# Altium schematic sheet FTDI_FT232R_USB_To_UART.SchDoc
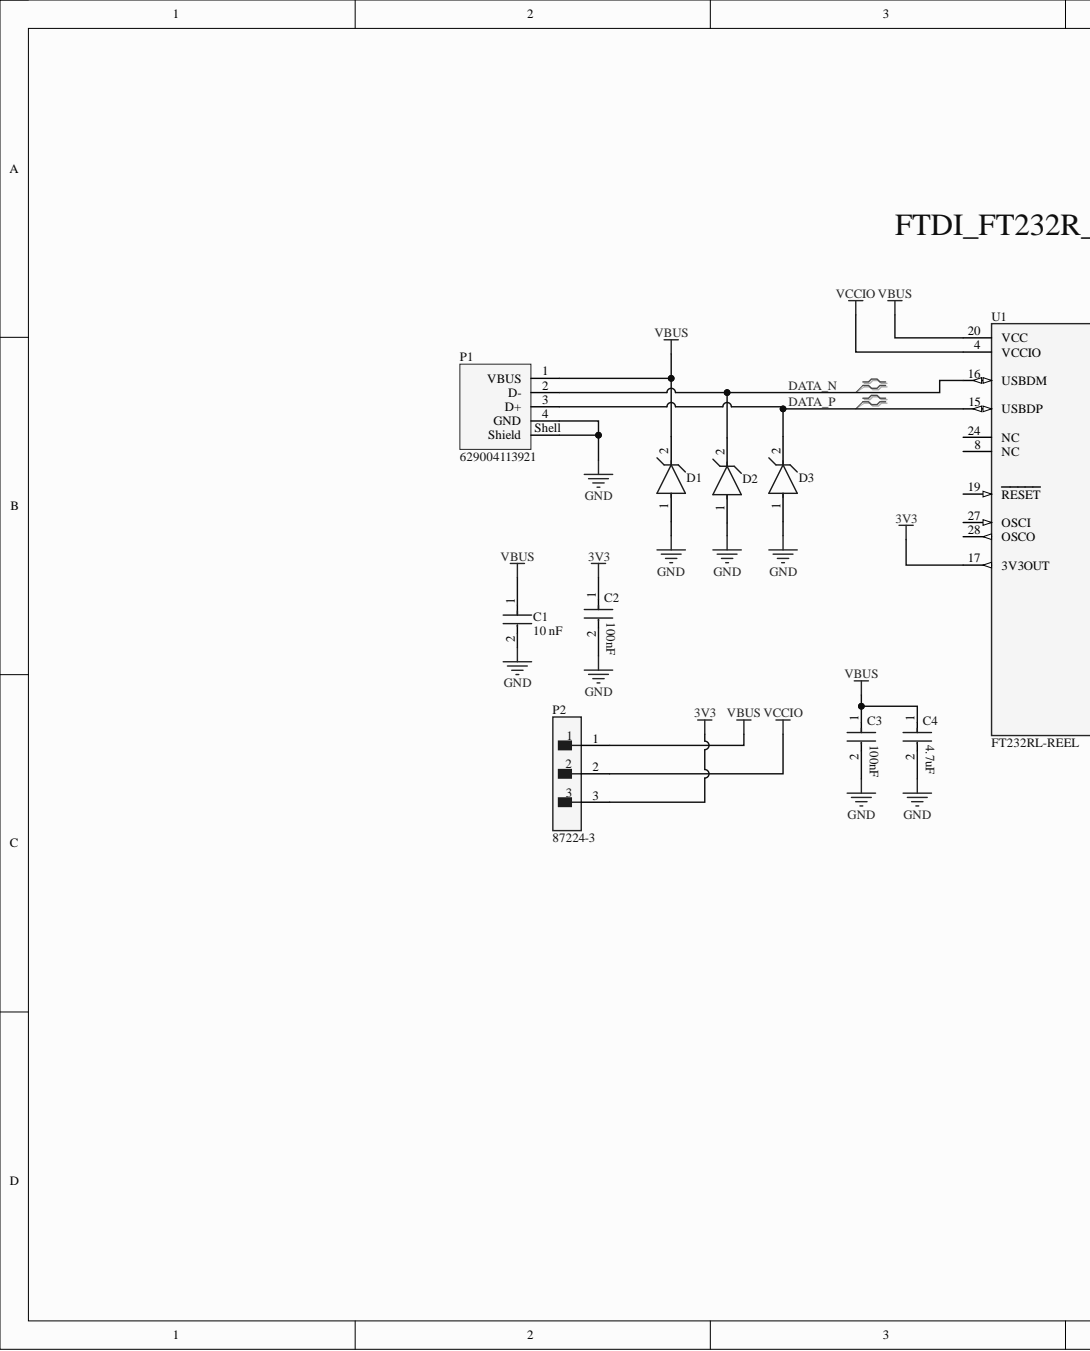
[diagram p0: FTDI_FT232R_USB_To_UART.SchDoc, left]
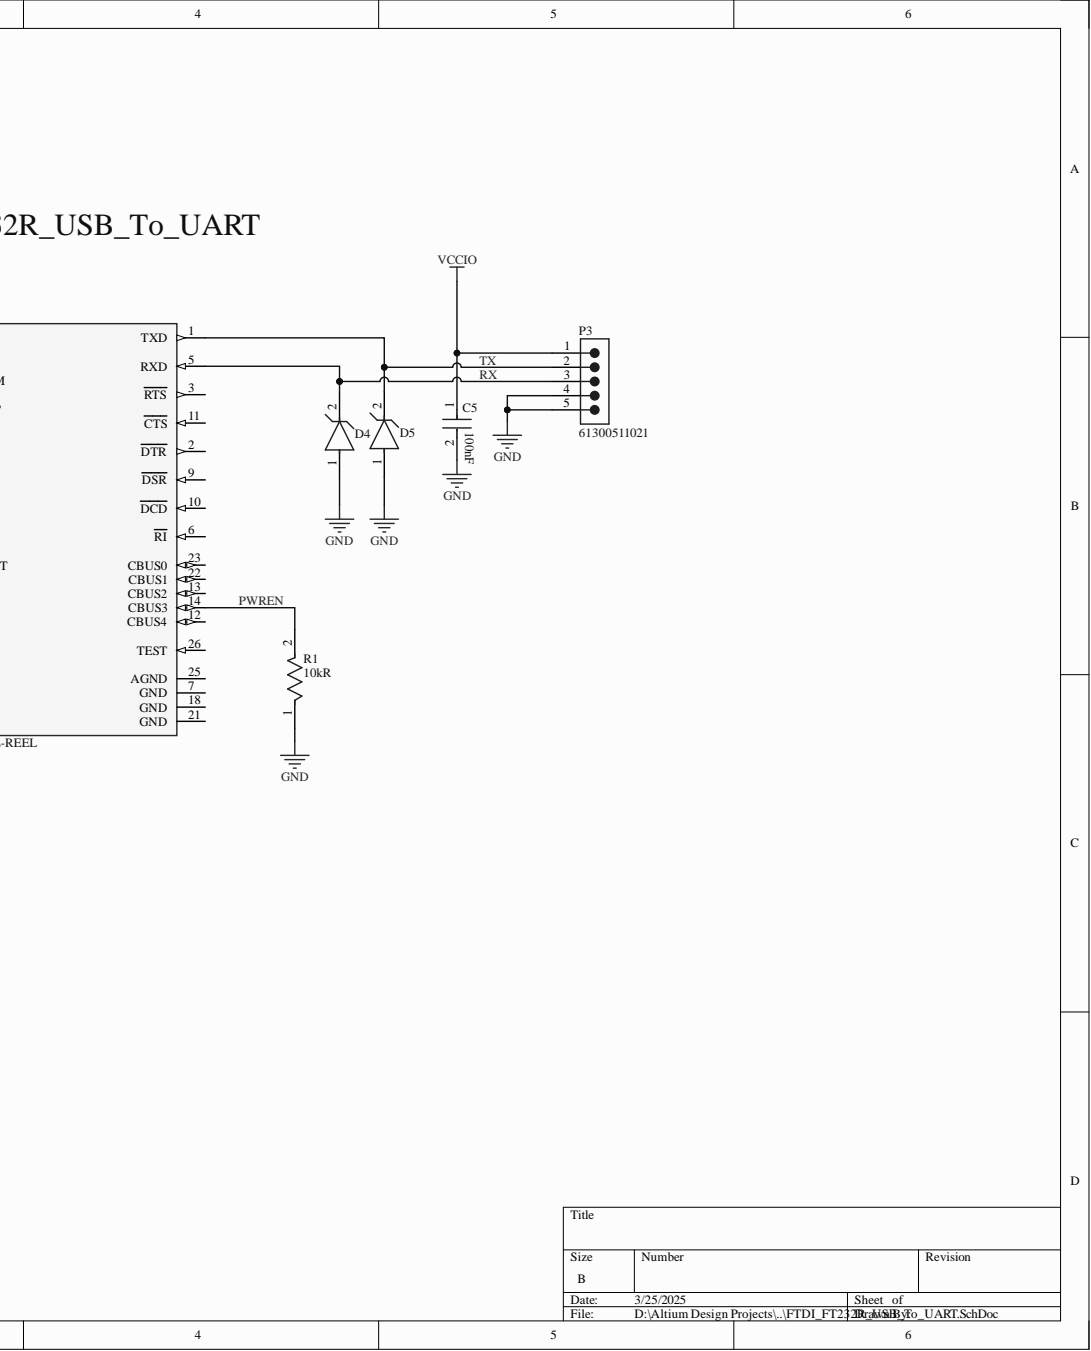
[diagram p1: FTDI_FT232R_USB_To_UART.SchDoc, right]

## Parts
C1  value 10 nF  Capacitance: 10 nF  [FP-CC0402-MFG]  (hidden comment: CC0402KPX7R7BB103)
C2  Capacitance: 100nF  [FP-C1005-050-0_05-MFG]  (hidden comment: C1005X7R1C104K050BC)
C3  Capacitance: 100nF  [FP-C1005-050-0_05-MFG]  (hidden comment: C1005X7R1C104K050BC)
C4  Capacitance: 4.7uF  [FP-GRM155-0_15-MFG]  (hidden comment: GRM155R61A475MEAAD)
C5  Capacitance: 100nF  [FP-C1005-050-0_05-MFG]  (hidden comment: C1005X7R1C104K050BC)
D1  UCLAMP3601P.TNT  [FP-SLP1006P2-MFG]  (value not drawn)
D2  UCLAMP3601P.TNT  [FP-SLP1006P2-MFG]  (value not drawn)
D3  UCLAMP3601P.TNT  [FP-SLP1006P2-MFG]  (value not drawn)
D4  UCLAMP3601P.TNT  [FP-SLP1006P2-MFG]  (value not drawn)
D5  UCLAMP3601P.TNT  [FP-SLP1006P2-MFG]  (value not drawn)
P1  629004113921  [629004113921]
P2  87224-3  [FP-87224-3-MFG]
P3  61300511021  [61300511021]
R1  Resistance: 10kR  [FP-CRG0402-IPC_B]  (hidden comment: CRG0402F10K)
U1  FT232RL-REEL  [FP-SSOP-28-MFG]

## Nets
3V3: C2.1 P2.3 U1.17(3V3OUT)
GND: C1.2 C2.2 C3.2 C4.2 C5.2 D1.1 D2.1 D3.1 D4.1 D5.1 P1.4(GND) P1.Shell(Shield) P3.4 P3.5 R1.1
VBUS: C1.1 C3.1 C4.1 D1.2 P1.1(VBUS) P2.1 U1.20(VCC)
VCCIO: C5.1 P2.2 P3.1 U1.4(VCCIO)
DATA_N: D2.2 P1.2(D-) U1.16(USBDM)
DATA_P: D3.2 P1.3(D+) U1.15(USBDP)
PWREN: R1.2 U1.14(CBUS3)
RX: D4.2 P3.3 U1.5(RXD)
TX: D5.2 P3.2 U1.1(TXD)

Not connected: U1 2(DTR) 3(RTS) 6(RI) 7(GND) 8(NC) 9(DSR) 10(DCD) 11(CTS) 12(CBUS4) 13(CBUS2) 18(GND) 19(RESET) 21(GND) 22(CBUS1) 23(CBUS0) 24(NC) 25(AGND) 26(TEST) 27(OSCI) 28(OSCO)

## Library data of the parts
C1 = CMP-03422-000420-1: CC0402 10 nF X7R 10.00% 16 V; Manufacturer: YAGEO; Automotive Grade: NA; Material: Ceramic; Temperature Coefficient: 30ppm/°C; Tolerance: 0.1; Voltage Rating: 16 V; Automotive: No; Category: Cap; Component Kind: Standard; ComponentLink2Description: Datasheet; ComponentLink2URL: http://www.yageo.com/documents/recent/UPY-GP_NP0_16V-to-50V_16.pdf; Device Class L1: Passive Components; Device Class L2: Capacitors; Device Class L3: Ceramic Capacitors; Footprint Url: http://www.yageo.com/exep/pages/download/literatures/UPY-C_GEN_24.pdf; Height: 0.55mm; Ipc Land Pattern Name: CAPC10050X50; Lead Free: Yes; Library Name: Altium Content Vault; Manufacturer Part Number: CC0402KPX7R7BB103; Mouser Description: Multilayer Ceramic Capacitors MLCC - SMD/SMT 10nF 16V X7R 10%; Octopart: https://octopart.com/cc0402kpx7r7bb103-yageo-12885102; Package: CC0402; RoHS: TRUE; Temperature: -55°C to +125°C; Temperature Characteristic: X7R; Temperature Range High: +125°C; Temperature Range Low: -55°C
C2 C3 C5 = CMP-08246-004182-1: Multilayer Ceramic Capacitors 100nF ±10% 16V X7R SMD 0402; Case Code (Imperial): 0402; Case Code (Metric): 1005; Case/Package: 0402; Component Kind: Standard; Construction: Flat; Depth: 0.5mm; Dielectric: X7R; Dielectric Material: Ceramic; Height: 0.5mm; Lead Free: Lead Free; Length: 1mm; Library Name: Altium Content Vault; Max Operating Temperature: 125°C; Min Operating Temperature: -55°C; Mount: Surface Mount; Number of Pins: 2; Package Quantity: 1; Packaging: Tape and Reel; Radiation Hardening: No; Resistor Type: Ceramic; RoHS Compliant: Yes; Series: C; Termination: SMD/SMT; Thickness: 0.022inch; Tolerance: 10%; Voltage: 16V; Voltage Rating: 16V; Voltage Rating (DC): 16V; Weight: 2.3E-05oz; Width: 0.02inch
C4 = CMP-06035-003573-1: Chip Multilayer Ceramic Capacitors for General Purpose, 0402, 4.7uF, X5R, 15%, 20%, 10V; Case Code (Imperial): 0402; Case Code (Metric): 1005; Case/Package: 0402; Component Kind: Standard; Library Name: Altium Content Vault; Max Operating Temperature: 85°C; Min Operating Temperature: -55°C; Mount: Surface Mount; Packaging: Tape and Reel; Tolerance: 20%; Voltage Rating: 10V
D1-D5 = CMP-56445-000005-1: TVS DIODE 33VWM 60VC SLP1006P2; Clamping Voltage: 60V; Diode Type: ZENER; Direction: Unidirectional; Element Configuration: Single; Leakage Current: 1uA; Max Breakdown Voltage: 45V; Min Breakdown Voltage: 36V; Number of Unidirectional Channels: 1; Peak Pulse Current: 2A; Peak Pulse Power: 125W; Power Dissipation: 170W; Power Line Protection: No; Reverse Standoff Voltage: 33V; Case Code (Imperial): 0402; Case Code (Metric): 1006; Case/Package: SMD/SMT; Clamping Voltage-Max: 60V; Component Kind: Standard; Depth: 0.6mm; Lead Free: Lead Free; Length: 1mm; Library Name: Altium Content Vault; Max Operating Temperature: 125°C; Min Operating Temperature: -40°C; Mount: Surface Mount; Number of Pins: 2; Packaging: Tape and Reel; Radiation Hardening: No; Resistor Type: Zener; RoHS Compliant: Yes; Series: Automotive, AEC-Q100, uClamp®; Working Voltage: 33V
P1 = CMP-1502-03242-2: NexarPartId: 19991169; Connector Type: USB; Contact Resistance: 20mΩ; Current Rating: 1.5A; Gender: Male; Insulation Resistance: 1GΩ; Number of Contacts: 4; Operating Supply Voltage: 30V; USB Standard: USB 2.0; Component Kind: Standard; Contact Plating: Gold; Features: Shielded; Lead Free: Lead Free; Length: 18.8mm; Library Name: Altium Content Vault; Max Operating Temperature: 105°C; Min Operating Temperature: -40°C; Mount: Horizontal, Surface Mount; Number of Pins: 4; Orientation: Horizontal; REACH SVHC: Unknown; RoHS: Compliant; Termination: SMD/SMT
P2 = CMP-2000-05697-2: CONN HEADER VERT 3POS 2.54MM; Approvals: CSA; Board Standoff: No (Without); Connector Type: Plug; Contact Current Rating: 3A; Contact Post Length: 0.125inch; High Speed Serial Data Connector: No; Number of Contacts: 3; PCB Mount Retention: Without; PCB Mounting Orientation: Vertical; PCB Thickness: 1.397mm; Color: Black; Component Kind: Standard; Contact Gender: Male; Contact Material: Bronze; Contact Mating Length: 8.08mm; Contact Plating: Gold; Contact Protection: No (Without); Contact Shape: Square; Contact Type: Male Pin; Current Rating: 3A; Depth: 2.54mm; ELV: Compliant; Flammability Rating: UL94 V-0; Gasket: No (Without); High Temperature Housing: No; Housing Color: Black; Housing Material: Thermoplastic; Keyed: No; Lead Free: Lead Free; Length: 7.21mm; Library Name: Altium Content Vault; Mating Alignment: No (Without); Mating Connector Lock: No (Without); Max Operating Temperature: 105°C; Min Operating Temperature: -65°C; Mount: Through Hole; Number of Positions: 3; Number of Rows: 1; Orientation: Straight; Package Quantity: 520; Packaging: Carton; Pitch: 2.54mm; Radiation Hardening: No; RoHS Compliant: Yes; Row Spacing: 2.54mm; Selectively Loaded: No (Without); Series: AMPMODU MOD II; Stabilizers: No (Without); Strain Relief: No (Without); Termination: Through Hole
P3 = CMP-1502-01067-3: NexarPartId: 32855525; Connector Type: Male Pin; Contact Resistance: 20mΩ; Current Rating: 3A; Gender: Male; Number of Contacts: 5; Operating Supply Voltage: 250V; Pitch: 2.54mm; Color: Black; Component Kind: Standard; Contact Material: Copper; Contact Mating Length: 5.9944mm; Contact Plating: Gold; Lead Pitch: 2.54mm; Library Name: Altium Content Vault; Mount: Through Hole; Number of Pins: 5; Number of Positions: 5; Number of Rows: 1; Packaging: Bulk; REACH SVHC: Unknown; RoHS: Compliant; Termination: Solder
R1 = CMP-03211-011410-1: RES SMD 10K OHM 1% 1/16W 0402; Max Power Dissipation: 63mW; Number of Resistors: 1; Temperature Coefficient: 100ppm/°C; Voltage Rating: 50V; Case Code (Imperial): 0402; Case/Package: 0402; Component Kind: Standard; Construction: Rectangular; Depth: 0.5mm; ELV: Compliant; Height: 0.35mm; Lead Free: Lead Free; Length: 1mm; Library Name: Altium Content Vault; Max Operating Temperature: 125°C; Military Standard: Not; Min Operating Temperature: -55°C; Mount: Surface Mount; Number of Terminations: 2; Package Quantity: 10000; Packaging: Tape and Reel; Power Rating: 63mW; Radiation Hardening: No; REACH SVHC: No SVHC; Resistance Tolerance: 1%; Resistor Type: Thick Film; RoHS Compliant: Yes; Series: CRG, Neohm; Technology: THICK FILM; Termination: Solder; Tolerance: 1%; Voltage Rating (DC): 50V; Width: 0.02inch
U1 = CMP-0078-00029-4: IC USB FS SERIAL UART 28-SSOP; Data Rate: 12Mbps; Max Supply Voltage: 5.25V; Min Supply Voltage: 3.3V; Number of Channels: 1; Operating Supply Current: 15mA; Voltage: 5V; Case/Package: SSOP; Component Kind: Standard; Height: 1.75mm; Interface: UART; Lead Free: Lead Free; Length: 10.2mm; Library Name: Altium Content Vault; Max Operating Temperature: 85°C; Min Operating Temperature: -40°C; Mount: Surface Mount; Number of Pins: 28; Number of Transceivers: 1; Package Quantity: 2000; Packaging: Tape and Reel; Radiation Hardening: No; REACH SVHC: No SVHC; Weight: 0.080001oz; Width: 5.3mm
